# Altium schematic sheet Sense.SchDoc(Sense)
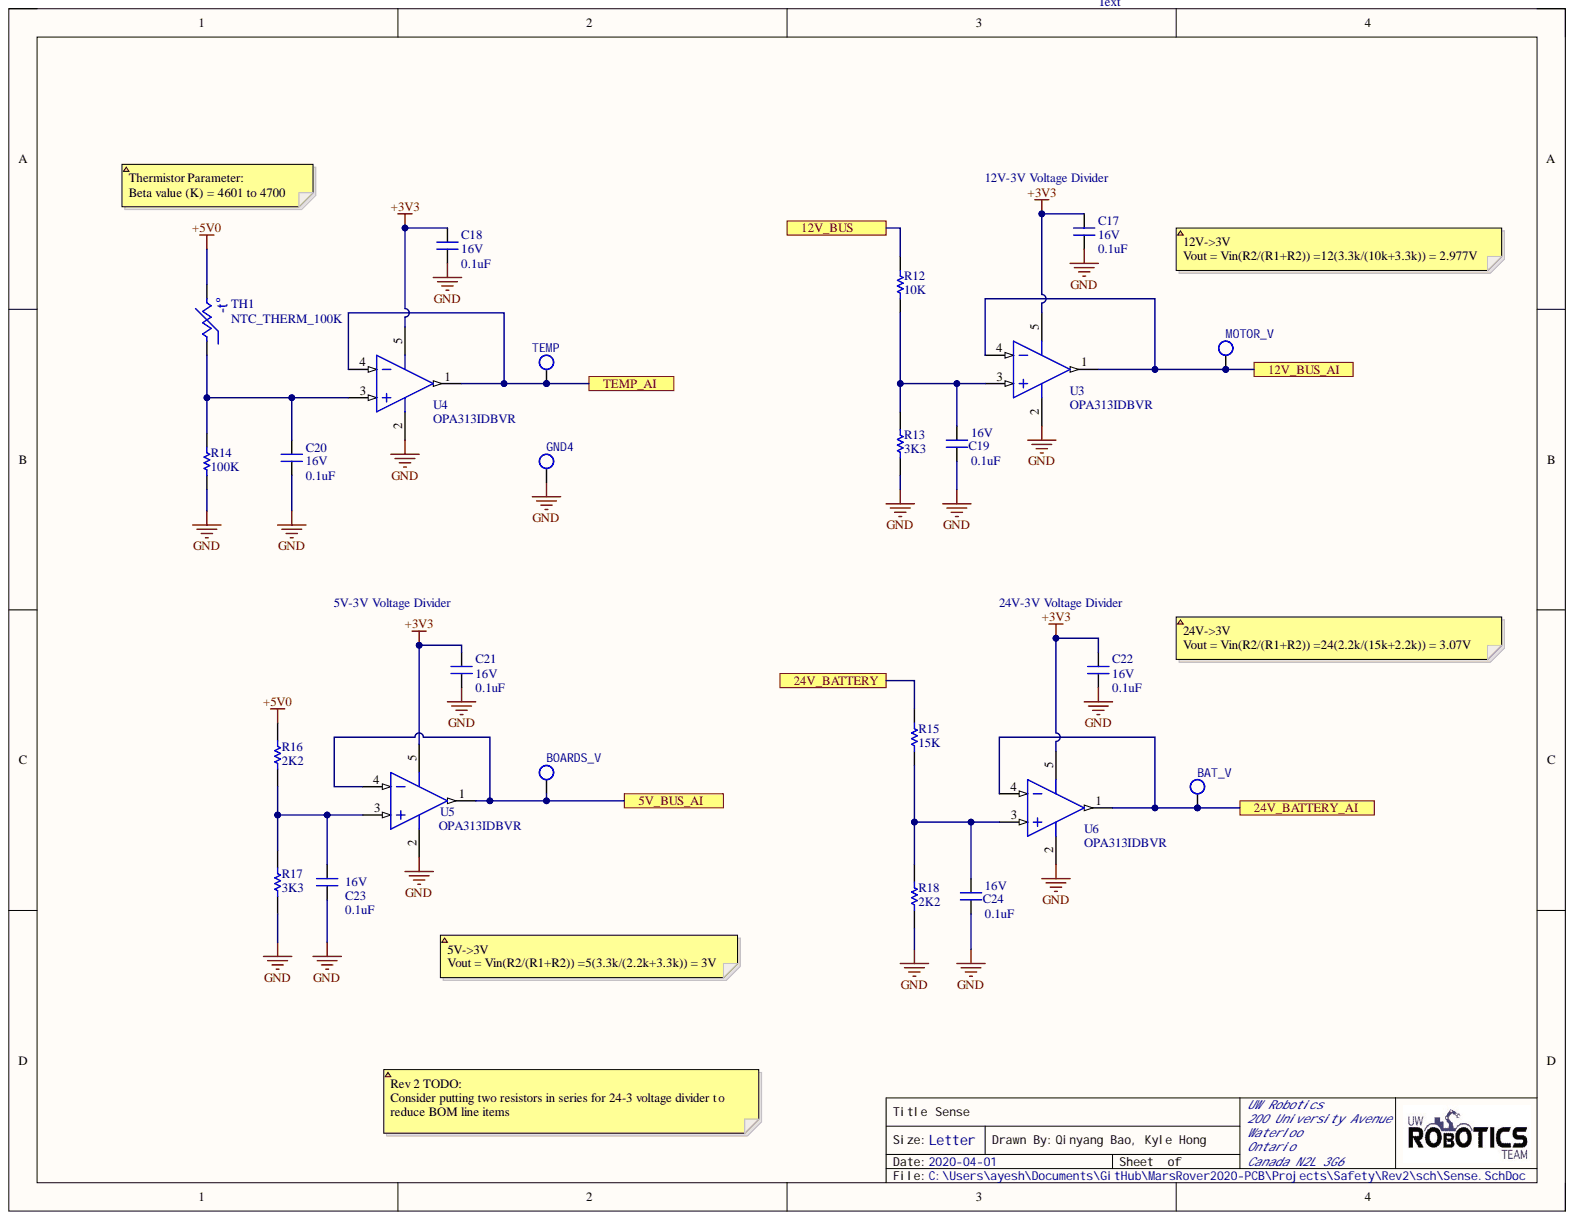
[diagram p0: Sense.SchDoc]

## Parts
BAT_V  TESTPOINT_LOOP  [TESTPOINT_LOOP]  (value not drawn)
BOARDS_V  TESTPOINT_LOOP  [TESTPOINT_LOOP]  (value not drawn)
C17  value 0.1uF  Voltage Rating: 16V  [CAPC0603(1608)55_N]  (hidden comment: CAP_0.1uF_16V_0603)
C18  value 0.1uF  Voltage Rating: 16V  [CAPC0603(1608)55_N]  (hidden comment: CAP_0.1uF_16V_0603)
C19  value 0.1uF  Voltage Rating: 16V  [CAPC0603(1608)55_N]  (hidden comment: CAP_0.1uF_16V_0603)
C20  value 0.1uF  Voltage Rating: 16V  [CAPC0603(1608)55_N]  (hidden comment: CAP_0.1uF_16V_0603)
C21  value 0.1uF  Voltage Rating: 16V  [CAPC0603(1608)55_N]  (hidden comment: CAP_0.1uF_16V_0603)
C22  value 0.1uF  Voltage Rating: 16V  [CAPC0603(1608)55_N]  (hidden comment: CAP_0.1uF_16V_0603)
C23  value 0.1uF  Voltage Rating: 16V  [CAPC0603(1608)55_N]  (hidden comment: CAP_0.1uF_16V_0603)
C24  value 0.1uF  Voltage Rating: 16V  [CAPC0603(1608)55_N]  (hidden comment: CAP_0.1uF_16V_0603)
GND4  TESTPOINT_LOOP  [TESTPOINT_LOOP]  (value not drawn)
MOTOR_V  TESTPOINT_LOOP  [TESTPOINT_LOOP]  (value not drawn)
R12  value 10K  [RESC0603(1608)_N]  (hidden comment: RES_10K_0603)
R13  value 3K3  [RESC0603(1608)_N]  (hidden comment: RES_3K3_0603)
R14  value 100K  [RESC0603(1608)_N]  (hidden comment: RES_100K_0603)
R15  value 15K  [RESC0603(1608)_N]  (hidden comment: RES_15K_0603)
R16  value 2K2  [RESC0603(1608)_N]  (hidden comment: RES_2K2_0603)
R17  value 3K3  [RESC0603(1608)_N]  (hidden comment: RES_3K3_0603)
R18  value 2K2  [RESC0603(1608)_N]  (hidden comment: RES_2K2_0603)
TEMP  TESTPOINT_LOOP  [TESTPOINT_LOOP]  (value not drawn)
TH1  NTC_THERM_100K  [RESC0603(1608)_N]
U3  OPA313IDBVR  [DBV0005A_M]
U4  OPA313IDBVR  [DBV0005A_M]
U5  OPA313IDBVR  [DBV0005A_M]
U6  OPA313IDBVR  [DBV0005A_M]

## Ports
5V_BUS_AI, 12V_BUS, 12V_BUS_AI, 24V_BATTERY, 24V_BATTERY_AI, TEMP_AI

## Nets
+3V3: C17.2 C18.1 C21.2 C22.2 U3.5 U4.5 U5.5 U6.5
+5V0: R16.2 TH1.1
GND: C17.1 C18.2 C19.1 C20.1 C21.1 C22.1 C23.1 C24.1 GND4.1 R13.1 R14.1 R17.1 R18.1 U3.2 U4.2 U5.2 U6.2
5V_BUS_AI: BOARDS_V.1 U5.1 U5.4 ; port 5V_BUS_AI
12V_BUS: R12.2 ; port 12V_BUS
12V_BUS_AI: MOTOR_V.1 U3.1 U3.4 ; port 12V_BUS_AI
24V_BATTERY: R15.2 ; port 24V_BATTERY
24V_BATTERY_AI: BAT_V.1 U6.1 U6.4 ; port 24V_BATTERY_AI
TEMP_AI: TEMP.1 U4.1 U4.4 ; port TEMP_AI
NetC19_2: C19.2 R12.1 R13.2 U3.3
NetC20_2: C20.2 R14.2 TH1.2 U4.3
NetC23_2: C23.2 R16.1 R17.2 U5.3
NetC24_2: C24.2 R15.1 R18.2 U6.3

## Library data of the parts
BAT_V BOARDS_V GND4 MOTOR_V TEMP = TESTPOINT_LOOP: Loop testpoint through-hole pad; Manufacturer: Keystone Electronics; Manufacturer Part Number 1: 5002; Component Kind: Standard; Supplier 1: Digi-Key; Supplier Part Number 1: 36-5002-ND; Library Name: UWRT_Schematic_Library_2020.SchLib
C17-C24 = CAP_0.1uF_16V_0603: CAP CER 0.1UF 16V X7R 0603; Manufacturer: Yageo; MPN: CC0603KRX7R7BB104; Component Kind: Standard; Dimensions: 0.063" L x 0.031" W (1.60mm x 0.80mm); Package: 0603 (1608 Metric); Signal Integrity: Cap; Simulation: CAP; Supplier 1: Digi-Key; Supplier Part Number 1: 311-1088-1-ND; Temperature Coefficient: X7R; Library Name: UWRT_Schematic_Library_2020.SchLib
R12 = RES_10K_0603: RES SMD 10K OHM 5% 1/10W 0603; Manufacturer: Yageo; MPN: RC0603JR-0710KL; Component Kind: Standard; Dimensions: 0.063" L x 0.031" W (1.60mm x 0.80mm); Package: 0603 (1608 Metric); Signal Integrity: Res; Simulation: RESISTOR; Supplier 1: Digi-Key; Supplier Part Number 1: 311-10KGRCT-ND; Tolerance: 5%; Library Name: UWRT_Schematic_Library_2020.SchLib
R13 R17 = RES_3K3_0603: RES SMD 3.3K OHM 5% 1/10W 0603; Manufacturer: Yageo; MPN: RC0603JR-073K3L; Component Kind: Standard; Digikey Part Number: 311-3.3KGRCT-ND; Dimensions: 0.063" L x 0.031" W (1.60mm x 0.80mm); Package: 0603 (1608 Metric); Signal Integrity: Res; Simulation: RESISTOR; Supplier 1: Digi-Key; Supplier Part Number 1: 311-3.3KGRCT-ND; Tolerance: 5%; Library Name: UWRT_Schematic_Library_2020.SchLib
R14 = RES_100K_0603: RES SMD 100K OHM 5% 1/10W 0603; Manufacturer: Yageo; MPN: RC0603FR-07261KL; Component Kind: Standard; Dimensions: 0.063" L x 0.031" W (1.60mm x 0.80mm); Package: 0603 (1608 Metric); Signal Integrity: Res; Simulation: RESISTOR; Supplier 1: Digi-Key; Supplier Part Number 1: 311-261KHRCT-ND; Tolerance: 5%; Library Name: UWRT_Schematic_Library_2020.SchLib
R15 = RES_15K_0603: RES SMD 15K OHM 5% 1/10W 0603; Manufacturer 1: Yageo; Manufacturer Part Number 1: RC0603JR-0715KL; Component Kind: Standard; Dimensions: 0.063" L x 0.031" W (1.60mm x 0.80mm); Package: 0603 (1608 Metric); Signal Integrity: Res; Simulation: RESISTOR; Supplier 1: Digi-Key; Supplier Part Number 1: 311-15KGRCT-ND; Tolerance: 5%; Library Name: UWRT_Schematic_Library_2020.SchLib
R16 R18 = RES_2K2_0603: RES SMD 2.2K OHM 1% 1/10W 0603; Manufacturer: Yageo; MPN: RC0603FR-072K2L; Component Kind: Standard; Dimensions: 0.063" L x 0.031" W (1.60mm x 0.80mm); Package: 0603 (1608 Metric); Signal Integrity: Res; Simulation: RESISTOR; Supplier 1: Digi-Key; Supplier Part Number 1: 311-2.20KHRCT-ND; Tolerance: 1%; Library Name: UWRT_Schematic_Library_2020.SchLib
TH1 = NTC_THERM_100K: THERM NTC 100KOHM 4700K 0603; Manufacturer: Panasonic; MPN: ERT-J1VV104J; Value: 100K; Component Kind: Standard; Supplier 1: Digi-Key; Supplier Part Number 1: P10555CT-ND; Library Name: UWRT_Schematic_Library_2020.SchLib
U3-U6 = OPAMP: IC OPAMP GP 1 CIRCUIT SOT23-5; Manufacturer: Texas Instruments; CMRR(Min)(dB): 70; Eco-Plan: Green (RoHS & no Sb/Br); GBW(Typ)(MHz): 1; Iq per channel(Max)(mA): 0.06; Offset Drift(Typ)(uV/C): 2; Packing: LARGE T&R; Rating: Catalog; Slew Rate(Typ)(V/us): 0.5; SubFamily: Low Input Bias Current Amp (<=10pA), Low Power Amp (<=500uA); Total Supply Voltage(Max)(+5V is 5, +/-5V is 10): 5.5; Total Supply Voltage(Min)(+5V is 5, +/-5V is 10): 1.8; Vio (25C)(Max)(mV): 2.5; Code_JEDEC: MO-178; Component Kind: Standard; ComponentLink1Description: Manufacturer URL; ComponentLink1URL: http://www.ti.com/; ComponentLink2Description: Datasheet; ComponentLink2URL: http://www.ti.com/lit/ds/symlink/opa313.pdf; ComponentLink3Description: Package Specification; ComponentLink3URL: http://www.ti.com/litv/pdf/mpds018i; DatasheetVersion: SBOS649C; Mounting Technology: Surface Mount; Number of Channels: 1; PackageDescription: 5-Pin Plastic Small Outline, Body 1.6 x 2.9 mm, Pitch 0.95 mm; PackageReference: DBV0005A; PackageVersion: 4073253-4/K, 03/2006; PartNumber: OPA313IDBVR; Supplier 1: Digi-Key; Supplier Part Number 1: 296-35607-1-ND; Library Name: UWRT_Schematic_Library_2020.SchLib
